# Altium schematic sheet Sheet1.SchDoc
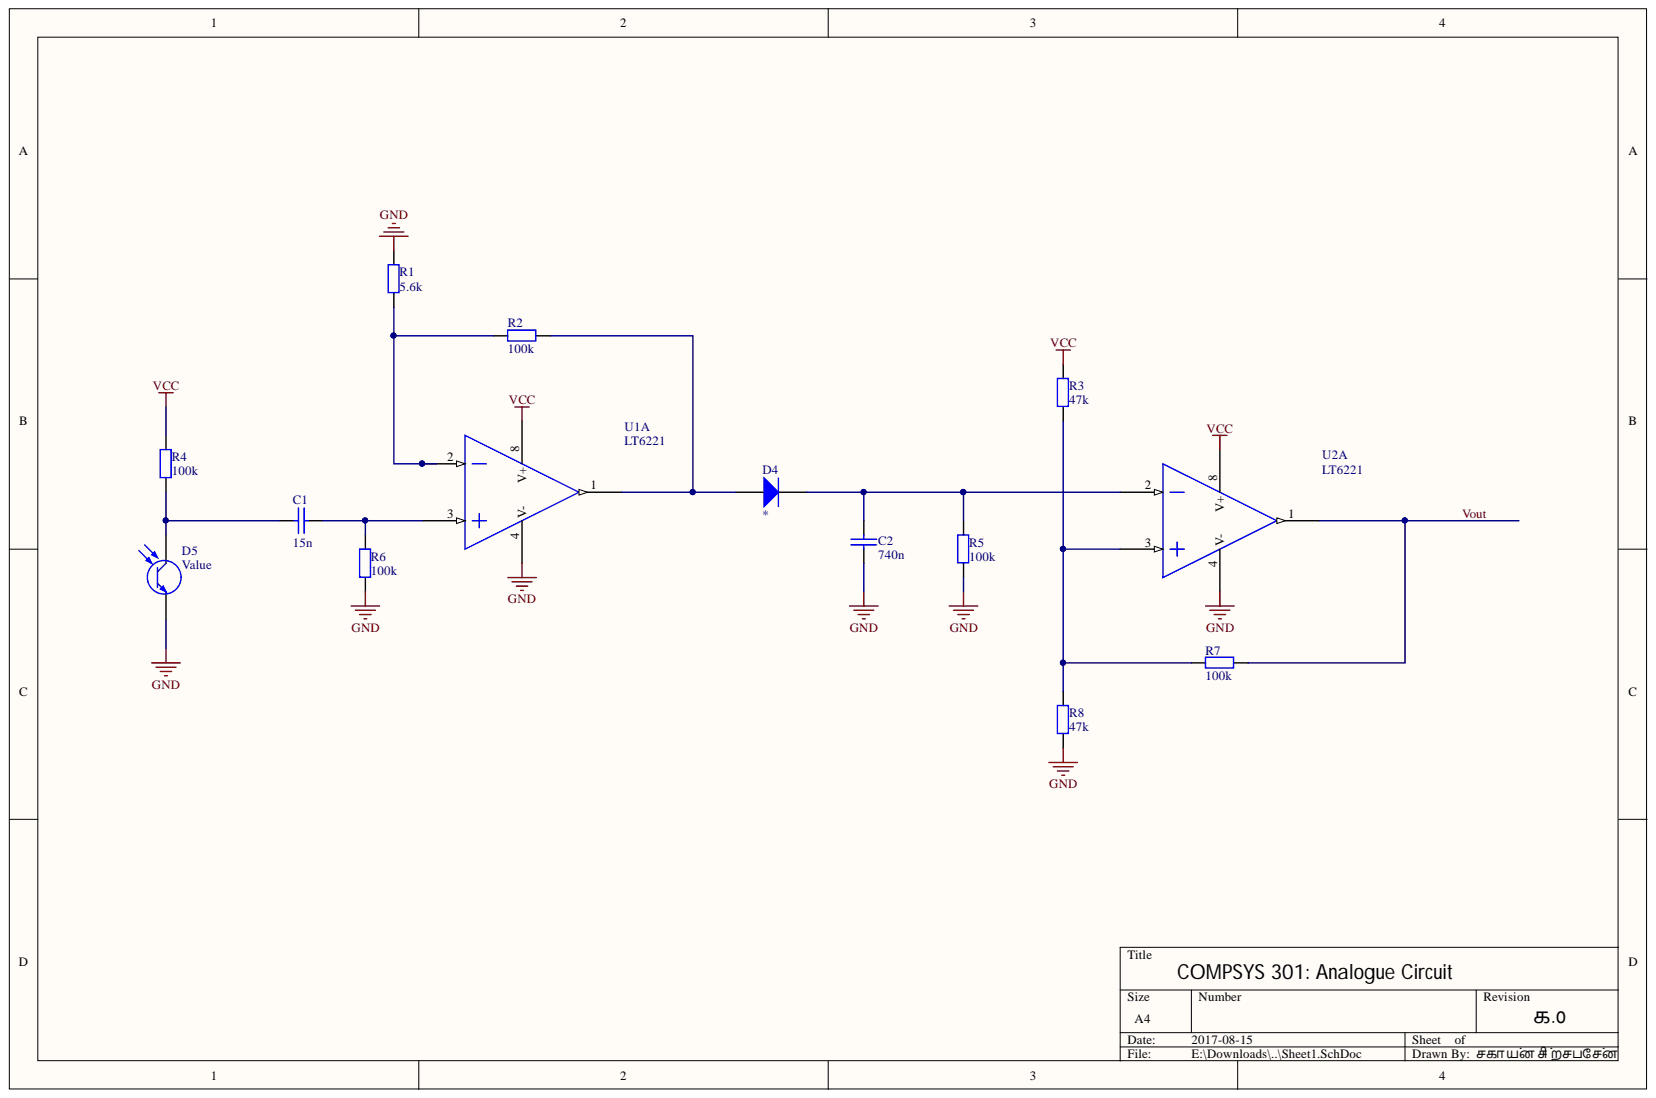
[diagram p0: Sheet1.SchDoc]

## Parts
C1  15n
C2  740n
D4  (no value)
D5  Value  [ECE-SENSOR-0805SM]
R1  5.6k
R2  100k
R3  47k
R4  100k
R5  100k
R6  100k
R7  100k
R8  47k
U1  LT6221  (part A)
U2  LT6221  (part A)

## Nets
GND: C2.1 D5.1 R1.2 R5.1 R6.1 R8.1 U1.4(V-) U2.4(V-)
VCC: R3.2 R4.2 U1.8(V+) U2.8(V+)
Vout: R7.2 U2.1
NetC1_1: C1.1 D5.2 R4.1
NetC1_2: C1.2 R6.2 U1.3
NetC2_2: C2.2 D4.2 R5.2 U2.2
NetD4_1: D4.1 R2.2 U1.1
NetR1_1: R1.1 R2.1 U1.2
NetR3_1: R3.1 R7.1 R8.2 U2.3

## Library data of the parts
C1 C2 = Cap: Capacitor; Component Kind: Standard; Library Name: EceParts.SchLib
  C1: Value: 15n
  C2: Value: 740n
D4 = Diode: Component Kind: Standard; Description: Diode; Library Name: EceParts.SchLib
D5 = TEMT6200: Light Sensor TEMT6200; Component Kind: Standard; Library Name: EceParts.SchLib; Revision: July-2002: Re-released for DXP Platform.
R1-R8 = Resistor: Component Kind: Standard; Description: Resistor; Library Name: EceParts.SchLib
  R1: Value: 5.6k
  R2 R4-R7: Value: 100k
  R3 R8: Value: 47k
U1 U2 = LT6221: Component Kind: Standard; Library Name: EceParts.SchLib
